# Altium schematic sheet 10_Module_2.SchDoc
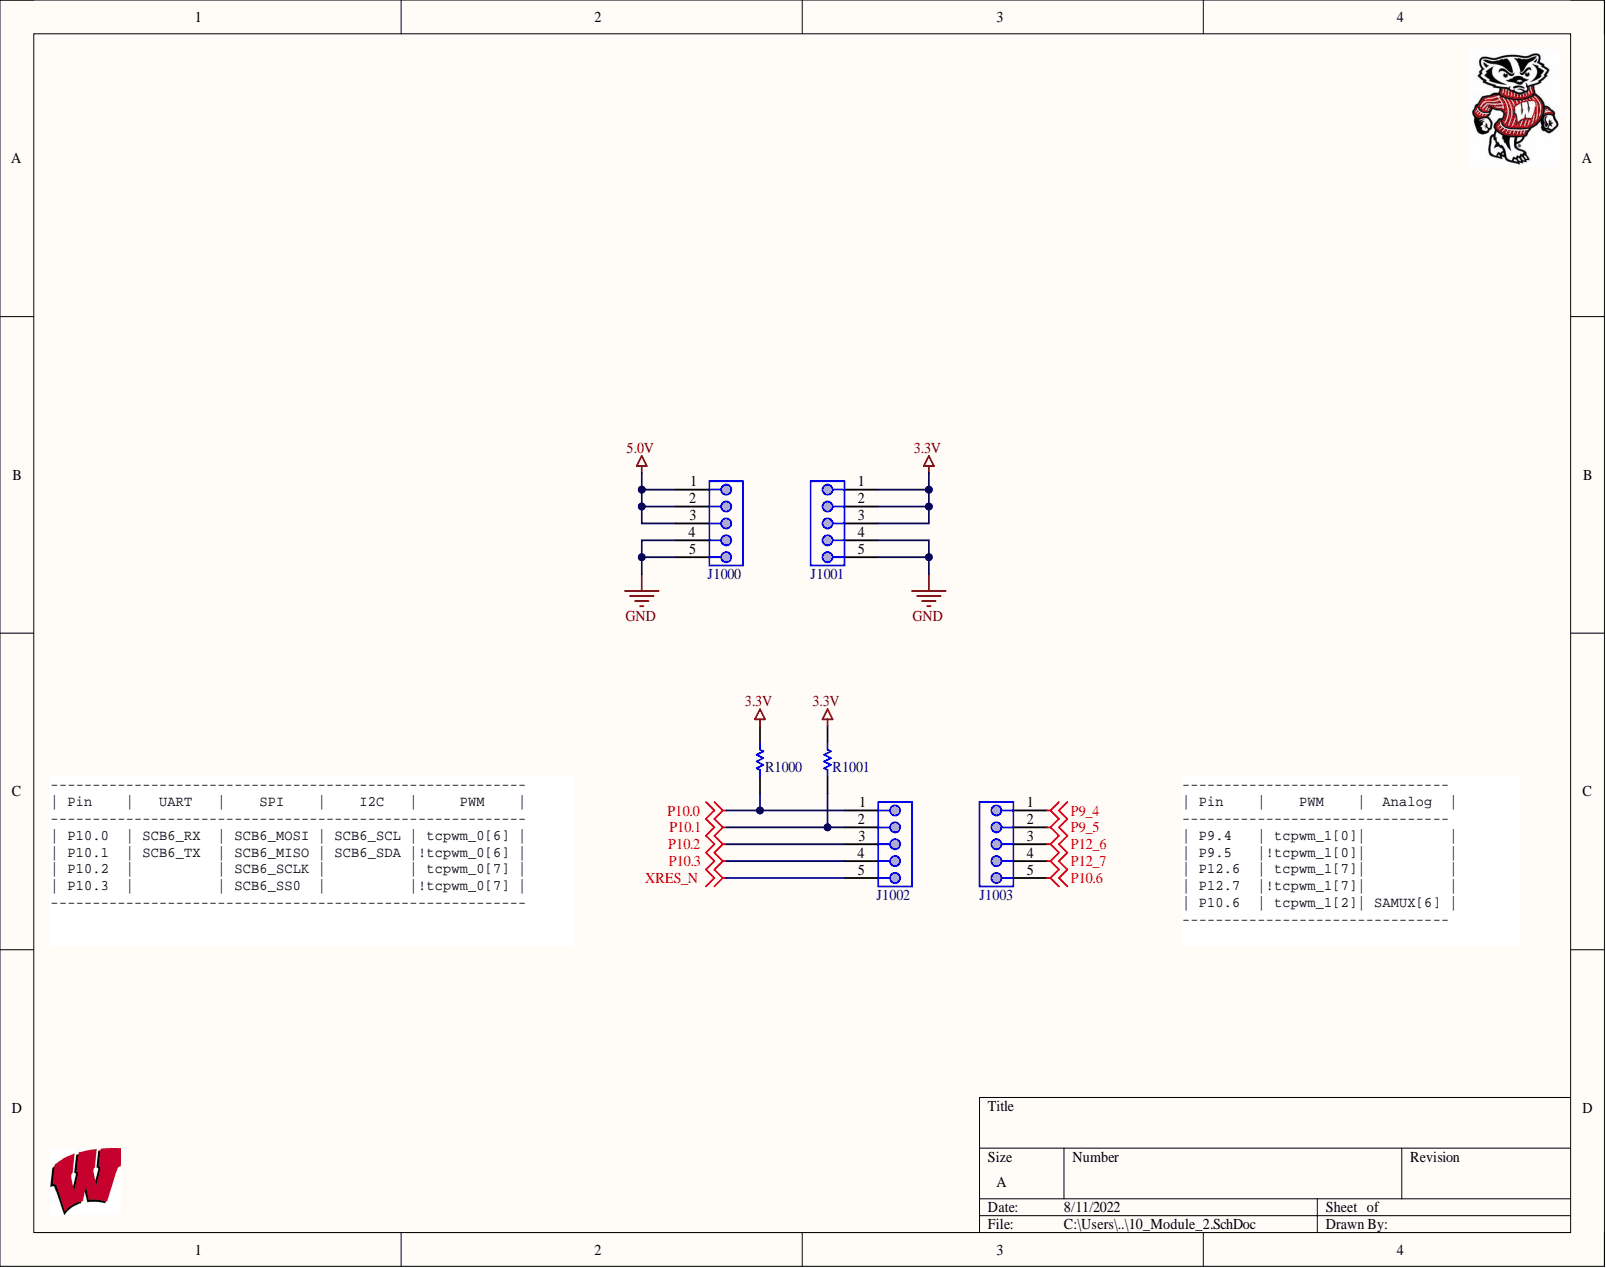
[diagram p0: 10_Module_2.SchDoc]

## Parts
J1000  CONN_HEADER_MALE_1x5  [HDR1X5]  (value not drawn)
J1001  CONN_HEADER_MALE_1x5  [HDR1X5]  (value not drawn)
J1002  CONN_HEADER_MALE_1x5  [HDR1X5]  (value not drawn)
J1003  CONN_HEADER_MALE_1x5  [HDR1X5]  (value not drawn)
R1000  RES_0805_K_010.00  [RES_0805]  (value not drawn)
R1001  RES_0805_K_010.00  [RES_0805]  (value not drawn)

## Nets
3.3V: J1001.1 J1001.2 J1001.3 R1000.2 R1001.2
5.0V: J1000.1 J1000.2 J1000.3
GND: J1000.4 J1000.5 J1001.4 J1001.5
P9_4: J1003.1
P9_5: J1003.2
P10.0: J1002.1 R1000.1
P10.1: J1002.2 R1001.1
P10.2: J1002.3
P10.3: J1002.4
P10.6: J1003.5
P12_6: J1003.3
P12_7: J1003.4
XRES_N: J1002.5

## Library data of the parts
J1000-J1003 = CONN_HEADER_MALE_1x5: Header, 5-Pin; Manufacturer 1: Sullins; Manufacturer Part Number 1: PREC005SACN-RC; Connector Type: Male Pin; Number of Positions: 5; Pitch: 0.1inch; Color: Black; Component Kind: Standard; ComponentLink1Description: http://www.farnell.com/cad/1737268.pdf; ComponentLink1URL: http://www.farnell.com/cad/1737268.pdf; ComponentLink2Description: http://katalog.we-online.de/em/datasheet/6130xx11121.pdf; ComponentLink2URL: http://katalog.we-online.de/em/datasheet/6130xx11121.pdf; ComponentLink3Description: https://datasheet.ciiva.com/741/xrxczzzsxxn-rc-st-11635-b-741840.pdf?src-supplier=Digi-Key; ComponentLink3URL: https://datasheet.ciiva.com/741/xrxczzzsxxn-rc-st-11635-b-741840.pdf?src-supplier=Digi-Key; Contact Mating Length: 0.23inch; Contact Plating: Tin; Library Name: Connectors.SchLib; Mount: Through Hole; Number of Rows: 1; Package Quantity: 1; Packaging: Bulk; Pricing 1: 1=0.19000, 10=0.17400, 25=0.12960, 50=0.11120, 100=0.10740, 250=0.09264, 500=0.08892 (USD); RoHS: Yes; Stock 1: 0; Supplier 1: Digi-Key; Supplier Part Number 1: S1032EC-05-ND; Termination: Solder
R1000 R1001 = RES_0805_K_010.00: RES SMD 10K OHM 1% 1/8W 0805; Manufacturer 1: Yageo; Manufacturer Part Number 1: RC0805FR-0710KL; Max Power Dissipation: 125mW; Resistance: 10kR; Temperature Coefficient: 100ppm/°C; Voltage Rating: 150V; Case Code (Imperial): 0805; Case Code (Metric): 2012; Case/Package: 0805; Category: Chip SMD Resistors; Component Kind: Standard; ComponentLink1Description: https://datasheet.ciiva.com/11808/0900766b8106beab-11808151.pdf?src-supplier=RSComponents; ComponentLink1URL: https://datasheet.ciiva.com/11808/0900766b8106beab-11808151.pdf?src-supplier=RSComponents; ComponentLink2Description: https://datasheet.ciiva.com/12669/rc0805-12669688.pdf?src-supplier=Mouser; ComponentLink2URL: https://datasheet.ciiva.com/12669/rc0805-12669688.pdf?src-supplier=Mouser; ComponentLink3Description: https://datasheet.ciiva.com/46447/444164-46447563.pdf?src-supplier=Element14; ComponentLink3URL: https://datasheet.ciiva.com/46447/444164-46447563.pdf?src-supplier=Element14; ComponentLink4Description: https://datasheet.ciiva.com/2456/pyu-r-int-thick-7-2456972.pdf?src-supplier=Digi-Key; ComponentLink4URL: https://datasheet.ciiva.com/2456/pyu-r-int-thick-7-2456972.pdf?src-supplier=Digi-Key; ComponentLink5Description: https://datasheet.ciiva.com/2456/pyu-r-int-thick-7-2456973.pdf?src-supplier=Digi-Key; ComponentLink5URL: https://datasheet.ciiva.com/2456/pyu-r-int-thick-7-2456973.pdf?src-supplier=Digi-Key; ComponentLink6Description: https://datasheet.ciiva.com/2456/pyu-r-int-thick-7-2456974.pdf?src-supplier=Digi-Key; ComponentLink6URL: https://datasheet.ciiva.com/2456/pyu-r-int-thick-7-2456974.pdf?src-supplier=Digi-Key; ComponentLink7Description: https://datasheet.ciiva.com/16747/rc0805-16747087.pdf?src-supplier=Future+Electronics; ComponentLink7URL: https://datasheet.ciiva.com/16747/rc0805-16747087.pdf?src-supplier=Future+Electronics; Construction: Rectangular; Depth: 1.25mm; Features: Moisture Resistant; Height: 0.024inch; Lead Free: Lead Free; Length: 2mm; Library Name: Resistors.SchLib; Max Operating Temperature: 155°C; Military Standard: Not; Min Operating Temperature: -55°C; Number of Terminations: 2; Package Quantity: 1; Packaging: Tape and Reel; Power Rating: 125mW; Pricing 1: 1=0.10000, 10=0.04200, 100=0.01690, 1000=0.00759, 2500=0.00659 (USD); REACH SVHC: Unknown; Resistance Tolerance: 1%; Resistor Type: Thick Film; RoHS: Yes; RoHS Compliant: Yes; Series: RC; Size Code: 0805; Stock 1: 7562122; Supplier 1: Digi-Key; Supplier Part Number 1: 311-10.0KCRCT-ND; Technology: THICK FILM; Termination: SMD/SMT; Tolerance: 1%; Width: 0.049inch
